# Altium schematic sheet Serial Power Supply - Main.SchDoc
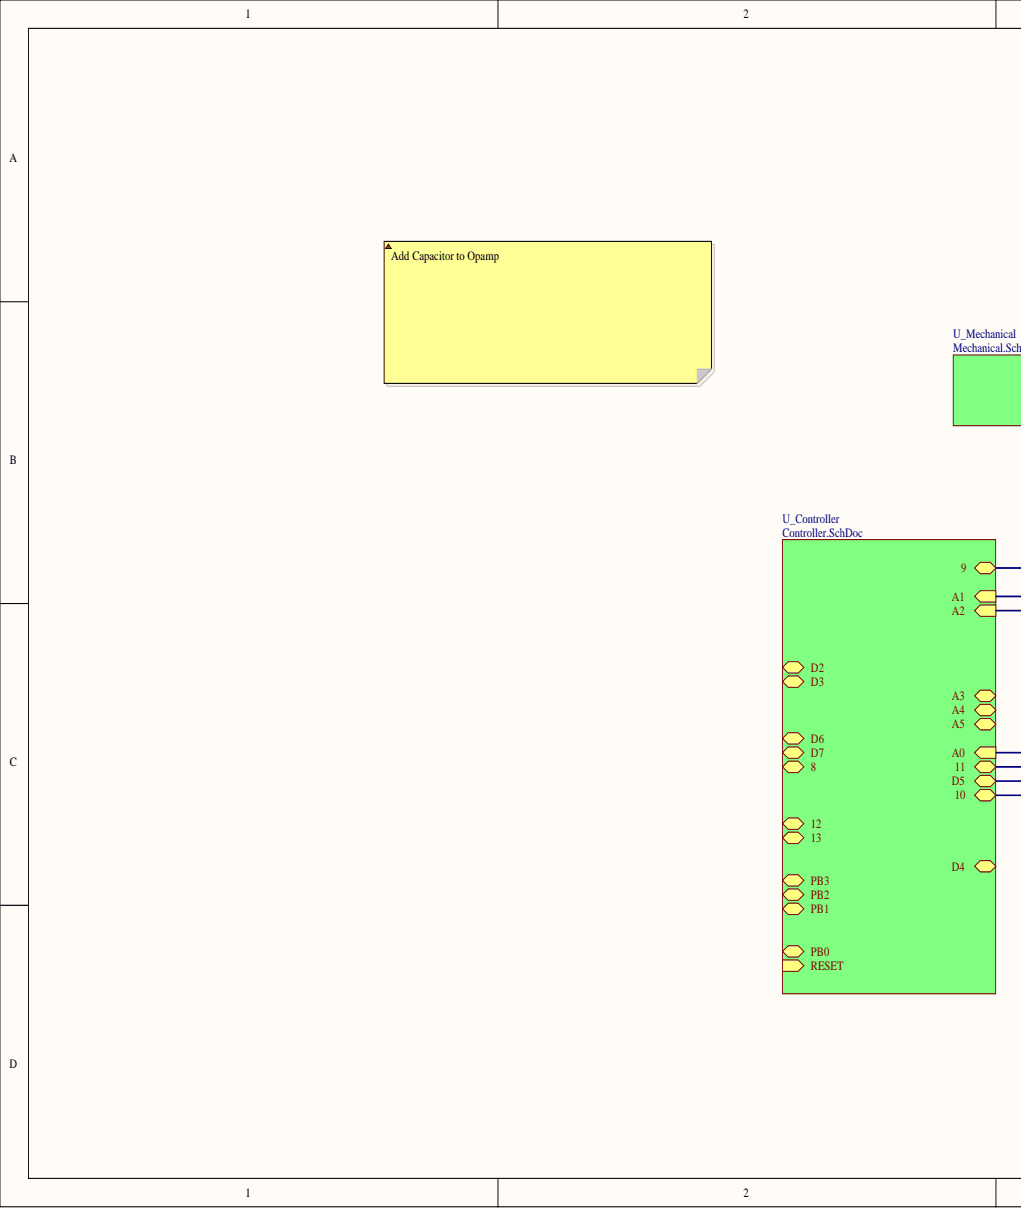
[diagram p0: Serial Power Supply - Main.SchDoc, left]
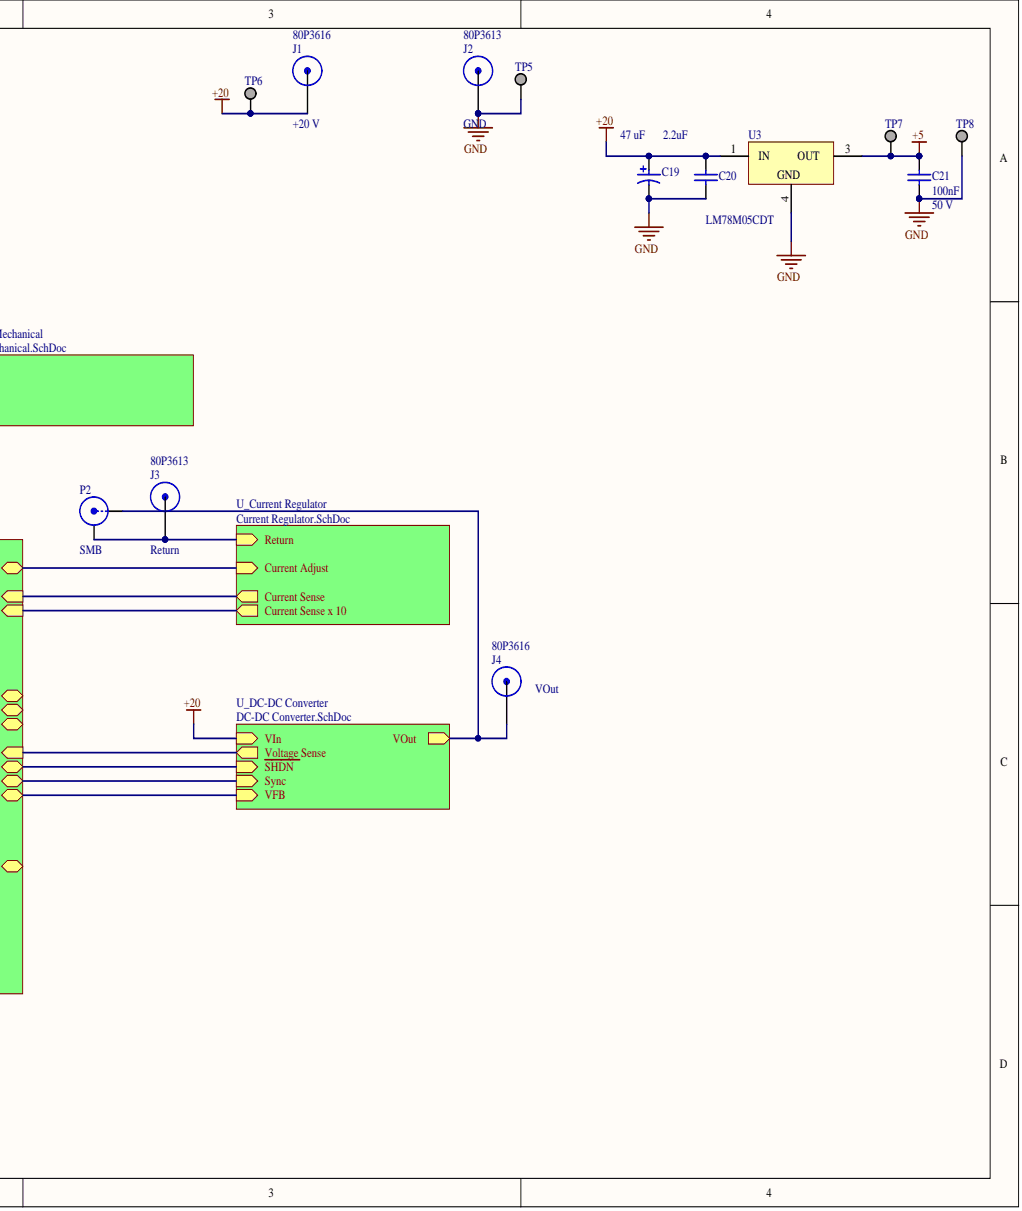
[diagram p1: Serial Power Supply - Main.SchDoc, right]

## Parts
C19  Rated Capacitance: 47 uF  [NIC-CAPA2-10X10_V]  (hidden comment: UCZ1J470MCS1GS)
C20  value 2.2uF  [CAPC1812(4532)280_L]  (hidden comment: CAP 2.2uF 100V 1812(4532))
C21  value 100nF  Rated Voltage: 50 V  [CAPC1206(3216)100_L]  (hidden comment: CAP 100nF 50V 1206(3216))
J1  +20 V  Supplier Part Number 1: 80P3616  [PCB-1keg917tfggg2k36z9zw-1]
J2  GND  Supplier Part Number 1: 80P3613  [PCB-1keg917tfggg2k36z9zw-1]
J3  Return  Supplier Part Number 1: 80P3613  [PCB-1keg917tfggg2k36z9zw-1]
J4  VOut  Supplier Part Number 1: 80P3616  [PCB-1keg917tfggg2k36z9zw-1]
P2  SMB  [SMB_V-RJ45]
TP5  GND  [KSTN-1502-2]  (value not drawn)
TP6  +20V  [KSTN-1502-2]  (value not drawn)
TP7  +5 V  [KSTN-1502-2]  (value not drawn)
TP8  GND  [KSTN-1502-2]  (value not drawn)
U3  LM78M05CDT  [TD03B_L]

## Nets
+5: C21.1 TP7.1 U3.3
+20: C19.1 C20.2 J1.1 TP6.1 U3.1
GND: C19.2 C20.1 C21.2 J2.1 TP5.1 TP8.1 U3.4
NetJ3_1: J3.1 P2.2
NetJ4_1: J4.1 P2.1
N00004:
N00005:
N00006:
N00007:
N00008:
N00009:
N00010:
N00011:
N00012:
N00013:
N00014:
N00015:
N00016:
N00017:
N00018:
N00019:
N00020:
N00021:
N00022:
N00023:
N00024:
N00025:
N00026:

## Library data of the parts
C19 = CMP-0687-00002-1: Aluminum Electrolytic Capacitor, UD Series, Low Impedance, 470 uF, 25 V, + / - 20%, -55 to 105 degC, Chip Type, 10 x 10 mm D x L, Pb-Free, Reel; Manufacturer: Nichicon; Endurance Time: 5000 h; Impedance: 0.09 Ohm; Packing: Dia382mm Reel; Rated Ripple Current (at 100kHz): 670 mA; Rated Voltage: 63V; Tolerance Rated Capacitance: -20 to 20%; Component Kind: Standard; ComponentLink1Description: Manufacturer URL; ComponentLink1URL: http://www.nichicon.co.jp/; ComponentLink2Description: Datasheet; ComponentLink2URL: http://products.nichicon.co.jp/en/pdf/XJA043/e-ud.pdf; ComponentLink3Description: Package Specification; ComponentLink3URL: http://products.nichicon.co.jp/en/pdf/XJA043/e-ud.pdf; DatasheetVersion: 08/2012; Diameter: 10 mm; Length: 10 mm; Library Name: Altium Content Vault; Mounting Technology: Surface Mount; PackageDescription: 2-Pin Surface Mount Device, Diameter 10 mm, Height 10 mm, Pitch 8 mm; PackageReference: CAPAE-D10H10; PartNumber: UCZ1J470MCS1GS; RoHS: TRUE; Supplier 1: Mouser; Supplier Part Number 1: 647-UCZ1J470MCS1GS
C20 = CMP-1040-01635-1: CAP 2.2uF 100V ±10% 1812 (4532 Metric) Thickness 2.8mm SMD; Case-EIA: 1812; Case-Metric: 4532; Tolerance: Â±10%; Component Kind: Standard; Library Name: Altium Content Vault; Max Thickness: 2.8 mm; Rated Voltage: 100 V; Supplier 1: Digi-Key; Supplier Part Number 1: 445-4510-2-ND; Technology: SMT; Value: 2.2uF
C21 = CMP-1037-04202-1: CAP 100nF 50V ±10% 1206 (3216 Metric) Thickness 1mm SMD; Case-EIA: 1206; Case-Metric: 3216; Tolerance: Â±10%; Component Kind: Standard; Library Name: Altium Content Vault; Max Thickness: 1 mm; Technology: SMT
J1 J4 = SYM-20399854-1: Banana Socket, 20a, 4mm, Pcb, Red; Component Kind: Standard; Library Name: SYM-20399854-2.SchLib; Supplier 1: Newark
J2 J3 = SYM-20399854-1: Banana Socket, 20a, 4mm, Pcb, Black; Component Kind: Standard; Library Name: SYM-20399854-2.SchLib; Supplier 1: Newark
P2 = SMB: SMB Straight Connector; Component Kind: Standard; LatestRevisionDate: 17-Jul-2002; LatestRevisionNote: Re-released for DXP Platform.; Library Name: Miscellaneous Connectors.IntLib; Signal Integrity: Connector; Publisher: Altium Limited
TP5-TP8 = CMP-1672-00001-4: Terminal DBL Turret, Through Hole, RoHS; Component Kind: Standard; Contact Finish: Tin; Contact Material: Brass; DatasheetVersion: Rev. A, 02/2008; Lead Pitch: 0.218 inch; Length: 2.77 mm; Library Name: Altium Content Vault; Mount: Through Hole; Mounting Technology: Through Hole; PackageDescription: 1-Pin Through Hole Device, Dia 3.18 mm; Package Quantity: 1; PackageReference: 1502-2; Pins: 50; REACH SVHC: No SVHC; RoHSCompliant: true; Supplier 1: Mouser; Supplier Part Number 1: 575-2501200800000
U3 = CMP-0062-00202-1: 3-Terminal Positive Voltage Regulators, 3-pin TO-252; Manufacturer: National Semiconductor; CompType: LDO IC; PackageTopMarking: NSUZXYTT*LM78M05*CDT; PowerWise: N; Code_JEDEC: TO-252-AA; Component Kind: Standard; DatasheetVersion: Aug-2005; Library Name: Altium Content Vault; PackageDescription: TO252, Molded, Jedec, 6.8 x 6.10 x 2.30mm Body, 3 Lead, 2.28mm Pitch, Surface Mount; PackageReference: TD03B; PackageVersion: revD, Feb-2009; PinCount: 3; RoHS: N
